# Altium schematic sheet SensorCaida.SchDoc(SensorCaida)
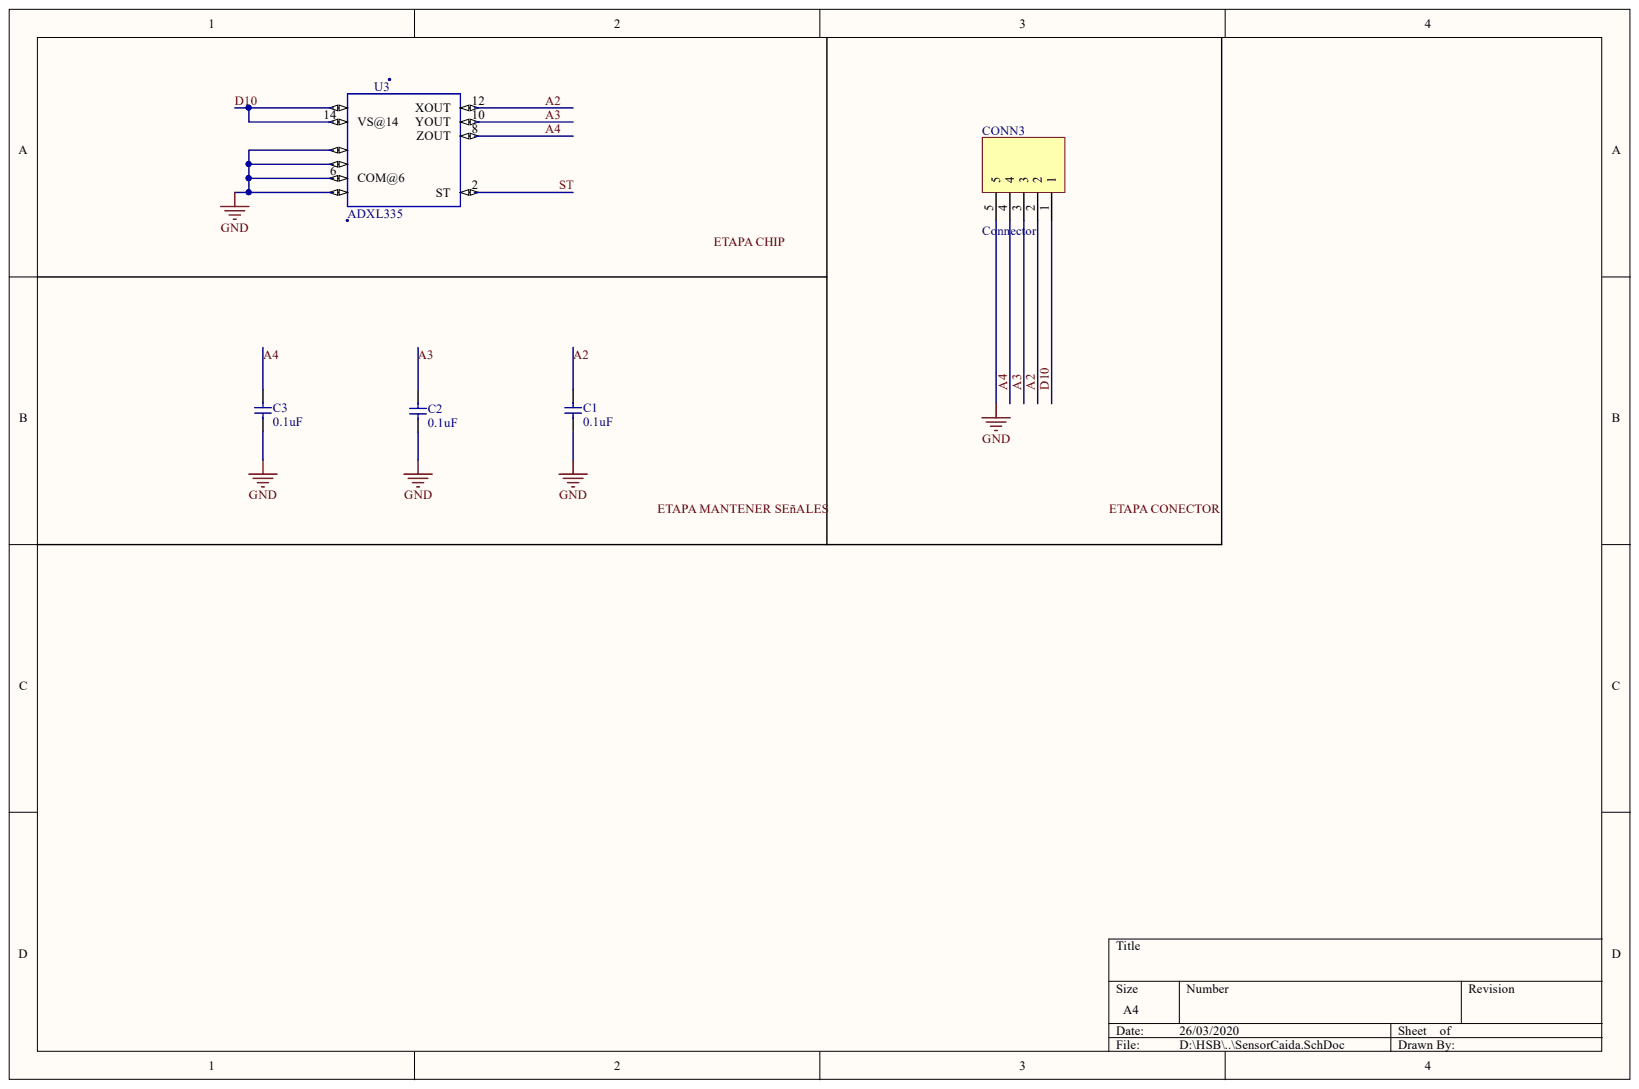
[diagram p0: SensorCaida.SchDoc]

## Parts
C1  C  value 0.1uF  [C1206-1.00]
C2  C  value 0.1uF  [C1206-1.00]
C3  C  value 0.1uF  [C1206-1.00]
CONN3  Connector  [1x5x2.54 Pin Header TH V]
U3  ADXL335  [*LFCSP-16]

## Nets
GND: C2.2 C3.2 CONN3.5 U3.3 U3.5 U3.6(COM@6) U3.7
ACELEROM-X: C1.1 CONN3.2 U3.12(XOUT)
ACELEROM-Y: CONN3.3 U3.10(YOUT)
ACELEROM-Z: C3.1 CONN3.4 U3.8(ZOUT)
ST: U3.2(ST)
VCC-ACELEROM: CONN3.1 U3.14(VS@14) U3.15
ETAPA CHIP:
ETAPA CONECTOR:
ETAPA MANTENER SEñALES:

Not connected: C1 2; C2 1

## Library data of the parts
C1-C3 = C: Capacitor, generic; Component Kind: Standard; Library Name: IFS_Altium.IntLib
CONN3 = Header 1x5: Component Kind: Standard; Library Name: IFS_Altium.IntLib
U3 = ADXL335: AcelerÃ³metro X, Y, Eje Z Â± 3g 1.6kHz _X, Y_, 550Hz _Z_ 16-LFCSP-LQ _4x4_; MF: Analog Devices; Availability: Unavailable; Component Kind: Standard; Library Name: ADXL335.SchLib; MP: ADXL335; Package: LFCSP-16 Analog Devices; Price: None; SnapEDA_Link: https://www.snapeda.com/parts/ADXL335/Analog%20Devices%20Inc./view-part/3215445/?ref=snap; URL: https://www.snapeda.com/home/
